# Altium schematic sheet [07]User Interface.SchDoc
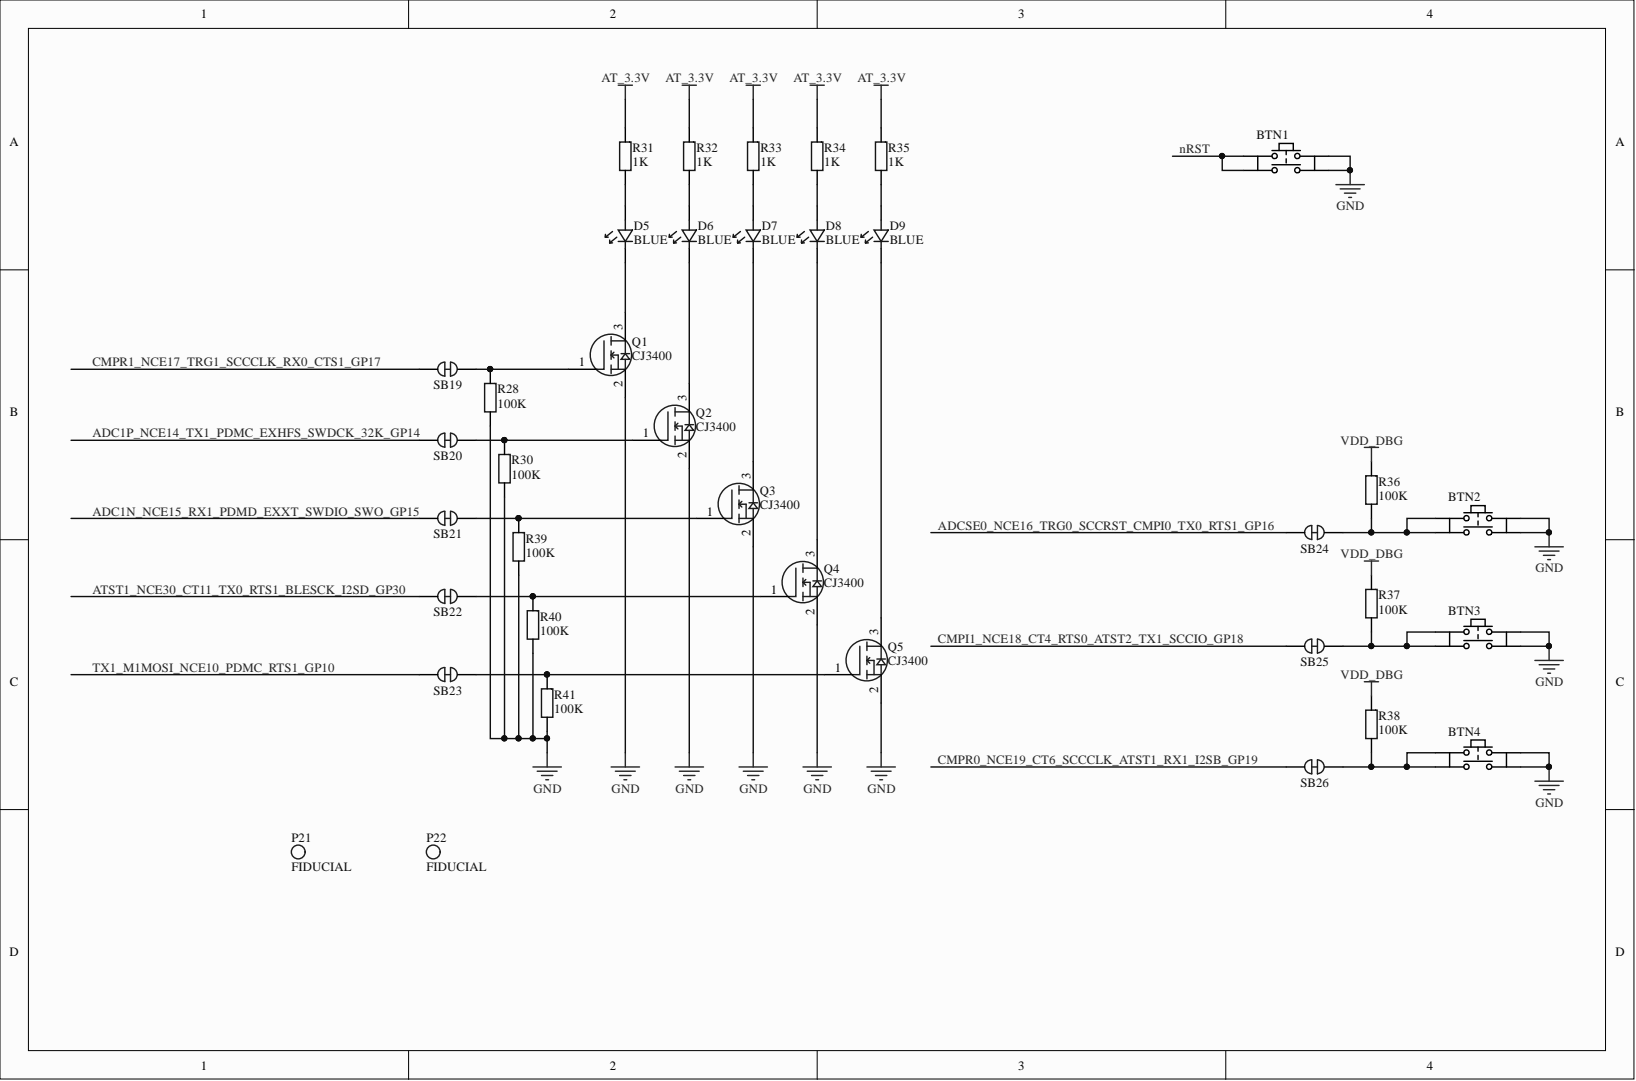
[diagram p0: [07]User Interface.SchDoc]

## Parts
BTN1  KFC-D003(4.3mm)  [TACT_TOP]  (value not drawn)
BTN2  KFC-D003(4.3mm)  [TACT_TOP]  (value not drawn)
BTN3  KFC-D003(4.3mm)  [TACT_TOP]  (value not drawn)
BTN4  KFC-D003(4.3mm)  [TACT_TOP]  (value not drawn)
D5  BLUE  [LEDC1608L]
D6  BLUE  [LEDC1608L]
D7  BLUE  [LEDC1608L]
D8  BLUE  [LEDC1608L]
D9  BLUE  [LEDC1608L]
P21  FIDUCIAL  [Fiducial]  (no BOM)
P22  FIDUCIAL  [Fiducial]  (no BOM)
Q1  CJ3400  [SOT23-3L]
Q2  CJ3400  [SOT23-3L]
Q3  CJ3400  [SOT23-3L]
Q4  CJ3400  [SOT23-3L]
Q5  CJ3400  [SOT23-3L]
R28  100K  [RESC1005L]
R30  100K  [RESC1005L]
R31  1K  [RESC1005L]
R32  1K  [RESC1005L]
R33  1K  [RESC1005L]
R34  1K  [RESC1005L]
R35  1K  [RESC1005L]
R36  100K  [RESC1005L]
R37  100K  [RESC1005L]
R38  100K  [RESC1005L]
R39  100K  [RESC1005L]
R40  100K  [RESC1005L]
R41  100K  [RESC1005L]
SB19  SBS  [SBS]  (value not drawn)
SB20  SBS  [SBS]  (value not drawn)
SB21  SBS  [SBS]  (value not drawn)
SB22  SBS  [SBS]  (value not drawn)
SB23  SBS  [SBS]  (value not drawn)
SB24  SBS  [SBS]  (value not drawn)
SB25  SBS  [SBS]  (value not drawn)
SB26  SBS  [SBS]  (value not drawn)

## Nets
AT_3.3V: R31.2 R32.2 R33.2 R34.2 R35.2
GND: BTN1.1 BTN1.4 BTN2.1 BTN2.4 BTN3.1 BTN3.4 BTN4.1 BTN4.4 Q1.2 Q2.2 Q3.2 Q4.2 Q5.2 R28.1 R30.1 R39.1 R40.1 R41.1
VDD_DBG: R36.2 R37.2 R38.2
ADC1N_NCE15_RX1_PDMD_EXXT_SWDIO_SWO_GP15: SB21.1
ADC1P_NCE14_TX1_PDMC_EXHFS_SWDCK_32K_GP14: SB20.1
ADCSE0_NCE16_TRG0_SCCRST_CMPI0_TX0_RTS1_GP16: SB24.1
ATST1_NCE30_CT11_TX0_RTS1_BLESCK_I2SD_GP30: SB22.1
CMPI1_NCE18_CT4_RTS0_ATST2_TX1_SCCIO_GP18: SB25.1
CMPR0_NCE19_CT6_SCCCLK_ATST1_RX1_I2SB_GP19: SB26.1
CMPR1_NCE17_TRG1_SCCCLK_RX0_CTS1_GP17: SB19.1
nRST: BTN1.2 BTN1.3
TX1_M1MOSI_NCE10_PDMC_RTS1_GP10: SB23.1
NetBTN2_2: BTN2.2 BTN2.3 R36.1 SB24.2
NetBTN3_2: BTN3.2 BTN3.3 R37.1 SB25.2
NetBTN4_2: BTN4.2 BTN4.3 R38.1 SB26.2
NetD5_1: D5.1 Q1.3
NetD5_2: D5.2 R31.1
NetD6_1: D6.1 Q2.3
NetD6_2: D6.2 R32.1
NetD7_1: D7.1 Q3.3
NetD7_2: D7.2 R33.1
NetD8_1: D8.1 Q4.3
NetD8_2: D8.2 R34.1
NetD9_1: D9.1 Q5.3
NetD9_2: D9.2 R35.1
NetQ1_1: Q1.1 R28.2 SB19.2
NetQ2_1: Q2.1 R30.2 SB20.2
NetQ3_1: Q3.1 R39.2 SB21.2
NetQ4_1: Q4.1 R40.2 SB22.2
NetQ5_1: Q5.1 R41.2 SB23.2

## Library data of the parts
BTN1-BTN4 = TACT_4PIN: Tactile Switch SPST-NO Top Actuated Surface Mount; Component Kind: Standard; Library Name: Discrete.SchLib
D5-D9 = LED_SMD: Surface Mount LED; Component Kind: Standard; Library Name: Discrete.SchLib
P21 P22 = FIDUCIAL: SMT Fiducial; Library Name: Discrete.SchLib
Q1-Q5 = MOS_N: N-Channel MOSFET; Component Kind: Standard; Library Name: Discrete.SchLib
R28 R30-R41 = RES_CHP: Surface Mount Resistor; Component Kind: Standard; Library Name: Discrete.SchLib
SB19-SB26 = SBS: Short-circuit soldering bridge; Component Kind: Net Tie; Library Name: Connector.SchLib
